# Altium schematic sheet V853_GPIO.SchDoc(V853_GPIO)
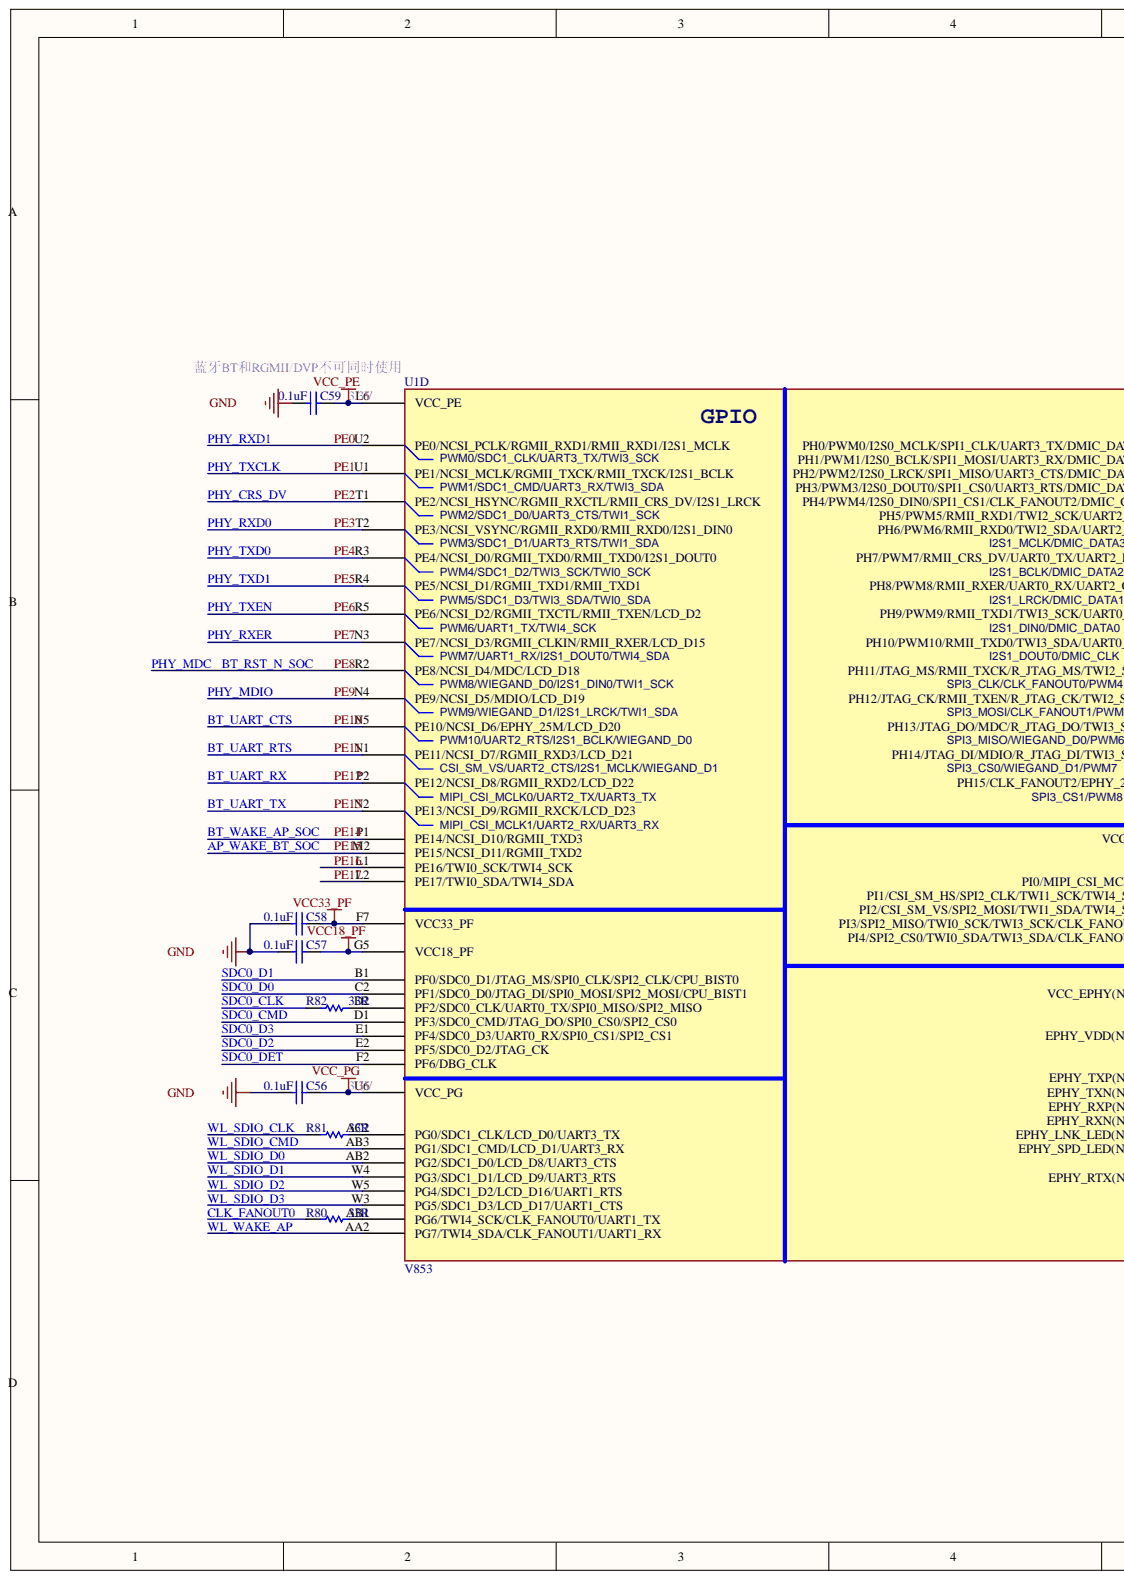
[diagram p0: V853_GPIO.SchDoc, left]
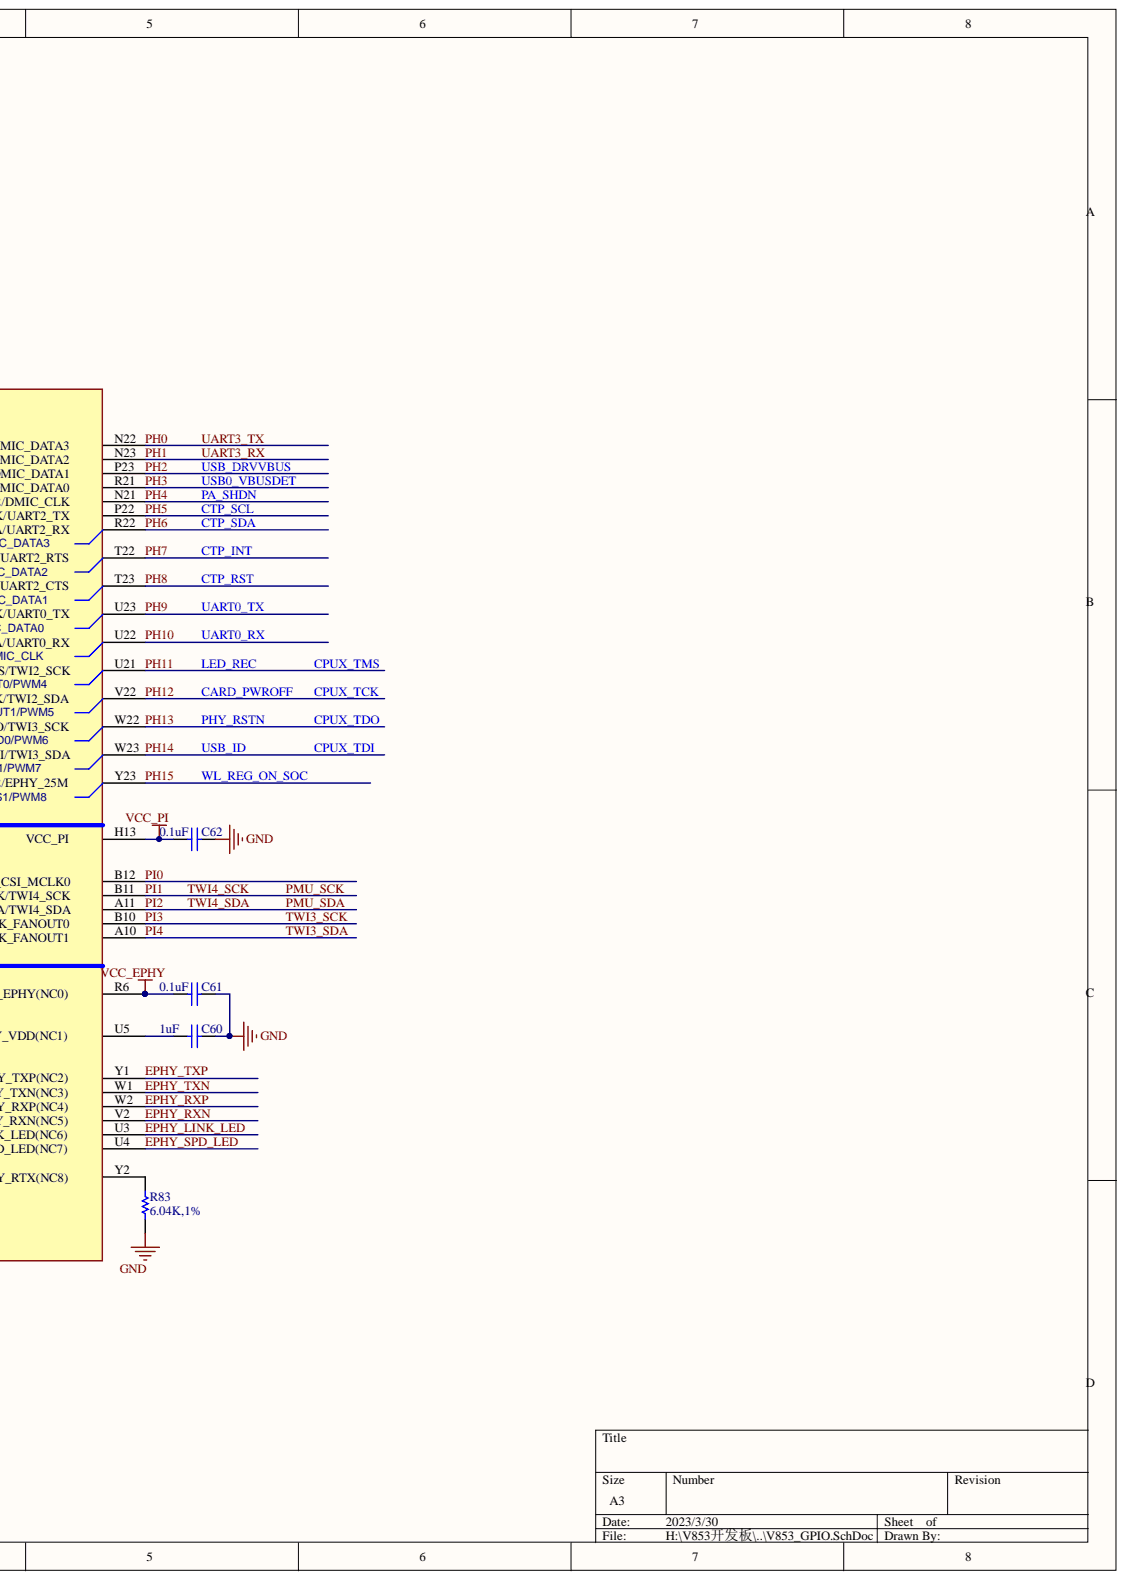
[diagram p1: V853_GPIO.SchDoc, right]

## Parts
C56  0.1uF  [C0201]
C57  0.1uF  [C0201]
C58  0.1uF  [C0201]
C59  0.1uF  [C0201]
C60  1uF  [0402]
C61  0.1uF  [C0201]
C62  0.1uF  [C0201]
R80  33R  [R0201]
R81  33R  [R0201]
R82  33R  [R0201]
R83  6.04K,1%  [R0201]
U1  V853  (part D)

## Nets
GND: C56.2 C57.2 C58.2 C59.2 C60.1 C61.1 C62.1 R83.2
VCC18_PF: C57.1 U1.G5(VCC18_PF)
VCC33_PF: C58.1 U1.F7(VCC33_PF)
VCC_EPHY: C61.2 U1.R6
VCC_PE: C59.1 U1.L6(VCC_PE)
VCC_PG: C56.1 U1.U6(VCC_PG)
VCC_PI: C62.2 U1.H13
AP_WAKE_BT_SOC: U1.M2(PE15/NCSI_D11/RGMII_TXD2)
BT_RST_N_SOC: U1.R2(PE8/NCSI_D4/MDC/LCD_D18)
BT_UART_CTS: U1.N5(PE10/NCSI_D6/EPHY_25M/LCD_D20)
BT_UART_RTS: U1.N1(PE11/NCSI_D7/RGMII_RXD3/LCD_D21)
BT_UART_RX: U1.P2(PE12/NCSI_D8/RGMII_RXD2/LCD_D22)
BT_UART_TX: U1.N2(PE13/NCSI_D9/RGMII_RXCK/LCD_D23)
BT_WAKE_AP_SOC: U1.P1(PE14/NCSI_D10/RGMII_TXD3)
CARD_PWROFF: U1.V22
CLK_FANOUT0: R80.2
CPUX_TDI: U1.W23
CPUX_TDO: U1.W22
CPUX_TMS: U1.U21
CTP_INT: U1.T22
CTP_RST: U1.T23
CTP_SCL: U1.P22
CTP_SDA: U1.R22
EPHY_LINK_LED: U1.U3
EPHY_RXN: U1.V2
EPHY_RXP: U1.W2
EPHY_SPD_LED: U1.U4
EPHY_TXN: U1.W1
EPHY_TXP: U1.Y1
PA_SHDN: U1.N21
PE0: U1.U2(PE0/NCSI_PCLK/RGMII_RXD1/RMII_RXD1/I2S1_MCLK)
PE1: U1.U1(PE1/NCSI_MCLK/RGMII_TXCK/RMII_TXCK/I2S1_BCLK)
PE2: U1.T1(PE2/NCSI_HSYNC/RGMII_RXCTL/RMII_CRS_DV/I2S1_LRCK)
PE3: U1.T2(PE3/NCSI_VSYNC/RGMII_RXD0/RMII_RXD0/I2S1_DIN0)
PE4: U1.R3(PE4/NCSI_D0/RGMII_TXD0/RMII_TXD0/I2S1_DOUT0)
PE5: U1.R4(PE5/NCSI_D1/RGMII_TXD1/RMII_TXD1)
PE6: U1.R5(PE6/NCSI_D2/RGMII_TXCTL/RMII_TXEN/LCD_D2)
PE7: U1.N3(PE7/NCSI_D3/RGMII_CLKIN/RMII_RXER/LCD_D15)
PE9: U1.N4(PE9/NCSI_D5/MDIO/LCD_D19)
PE16: U1.L1(PE16/TWI0_SCK/TWI4_SCK)
PE17: U1.L2(PE17/TWI0_SDA/TWI4_SDA)
PH0: U1.N22
PH1: U1.N23
PH2: U1.P23
PH3: U1.R21
PH9: U1.U23
PH10: U1.U22
PH15: U1.Y23
PI0: U1.B12
PI1: U1.B11
PI2: U1.A11
PI3: U1.B10
PI4: U1.A10
SDC0_CLK: R82.2
SDC0_CMD: U1.D1(PF3/SDC0_CMD/JTAG_DO/SPI0_CS0/SPI2_CS0)
SDC0_D0: U1.C2(PF1/SDC0_D0/JTAG_DI/SPI0_MOSI/SPI2_MOSI/CPU_BIST1)
SDC0_D1: U1.B1(PF0/SDC0_D1/JTAG_MS/SPI0_CLK/SPI2_CLK/CPU_BIST0)
SDC0_D2: U1.E2(PF5/SDC0_D2/JTAG_CK)
SDC0_D3: U1.E1(PF4/SDC0_D3/UART0_RX/SPI0_CS1/SPI2_CS1)
SDC0_DET: U1.F2(PF6/DBG_CLK)
WL_SDIO_CLK: R81.2
WL_SDIO_CMD: U1.AB3(PG1/SDC1_CMD/LCD_D1/UART3_RX)
WL_SDIO_D0: U1.AB2(PG2/SDC1_D0/LCD_D8/UART3_CTS)
WL_SDIO_D1: U1.W4(PG3/SDC1_D1/LCD_D9/UART3_RTS)
WL_SDIO_D2: U1.W5(PG4/SDC1_D2/LCD_D16/UART1_RTS)
WL_SDIO_D3: U1.W3(PG5/SDC1_D3/LCD_D17/UART1_CTS)
WL_WAKE_AP: U1.AA2(PG7/TWI4_SDA/CLK_FANOUT1/UART1_RX)
NetC60_2: C60.2 U1.U5
NetR80_1: R80.1 U1.AB1(PG6/TWI4_SCK/CLK_FANOUT0/UART1_TX)
NetR81_1: R81.1 U1.AC2(PG0/SDC1_CLK/LCD_D0/UART3_TX)
NetR82_1: R82.1 U1.D2(PF2/SDC0_CLK/UART0_TX/SPI0_MISO/SPI2_MISO)
NetR83_1: R83.1 U1.Y2

## Library data of the parts
C56-C62 = Cap: Capacitor; PartNumber: CAP100NF; Component Kind: Standard; LatestRevisionDate: 17-Jul-2002; LatestRevisionNote: Re-released for DXP Platform.; Library Name: Miscellaneous Devices.IntLib; PackageReference: 0402; Published: 8-Jun-2000; Signal Integrity: Cap; Simulation: CAP; Publisher: Altium Limited
  C56-C59 C61 C62: Value: 0.1uF
  C60: Value: 1uF
R80-R83 = Res1: Resistor; PartNumber: RES2.2K; Component Kind: Standard; LatestRevisionDate: 17-Jul-2002; LatestRevisionNote: Re-released for DXP Platform.; Library Name: Miscellaneous Devices.IntLib; PackageReference: 0402; Published: 8-Jun-2000; Signal Integrity: Res; Simulation: RESISTOR; Publisher: Altium Limited
  R80-R82: Value: 33R
  R83: Value: 6.04K,1%
U1 = V853_0621: PCB Footprint: BGA318P50B1200_1200H113; SPLIT_INST: TRUE; SWAP_INFO: (S1+S2+S3+S4+S5+S6+S7+S8+S9); Component Kind: Standard; Library Name: V853_CORE_20230224.SCHLIB
